# Altium schematic sheet ESC.SchDoc
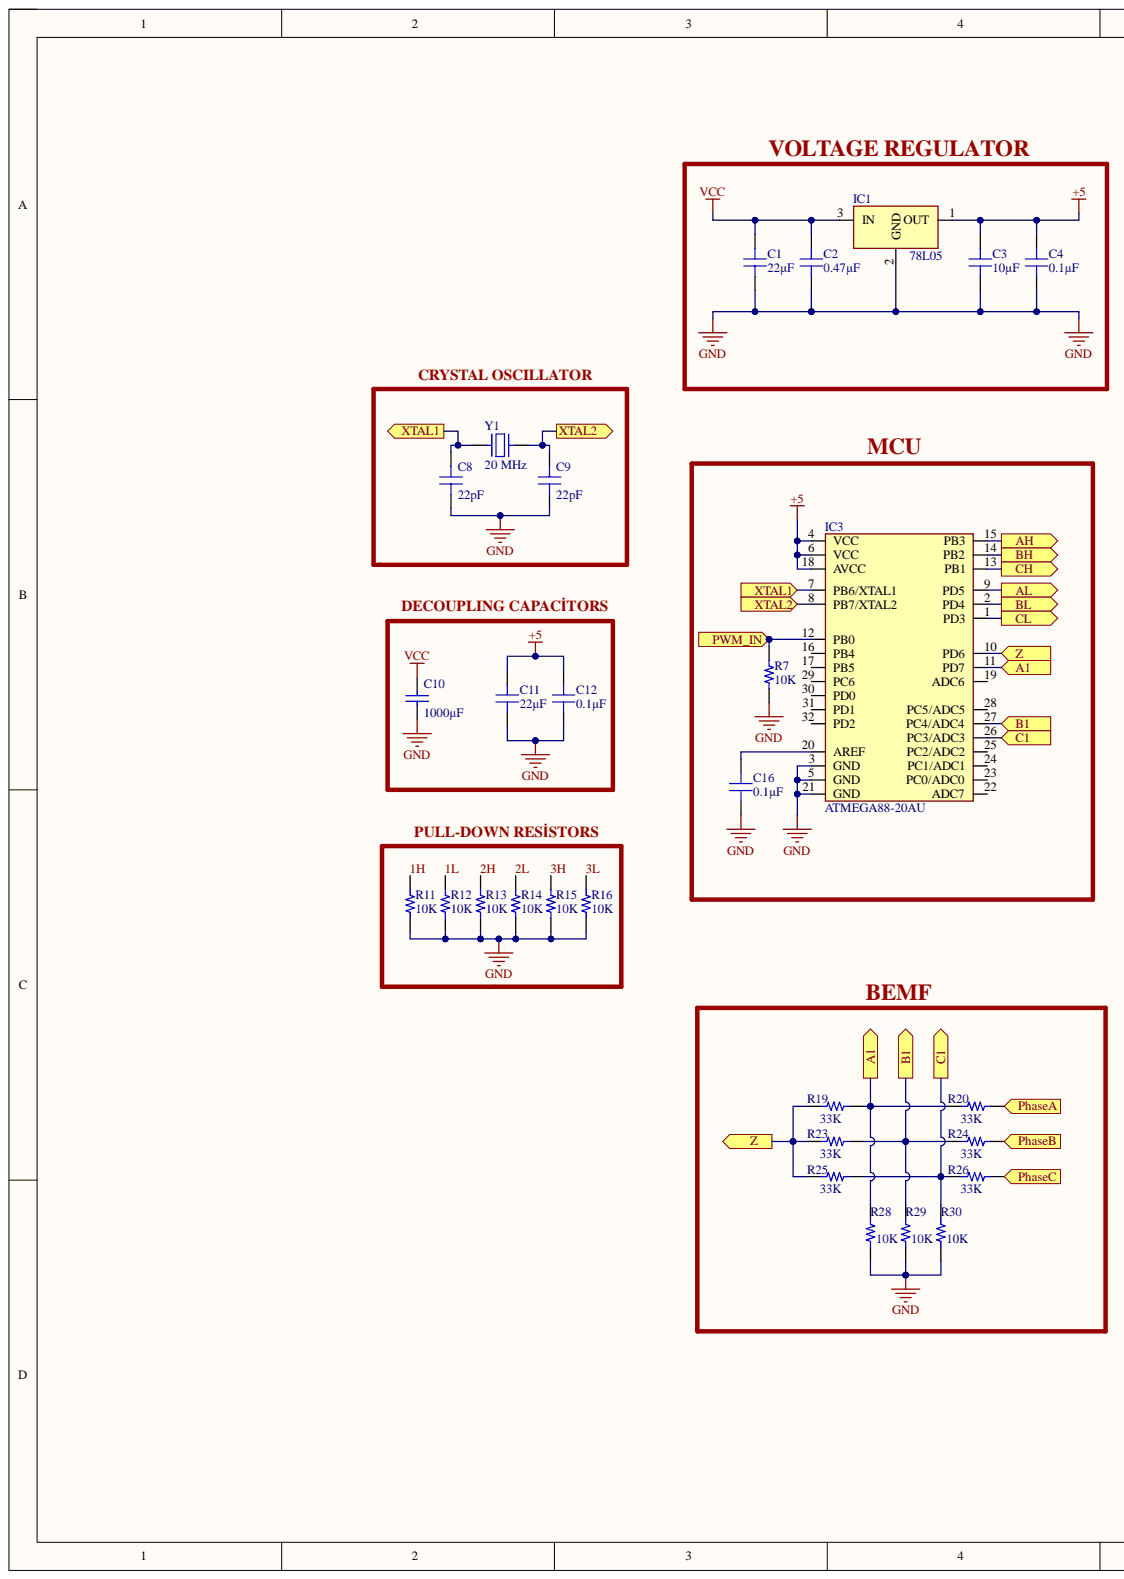
[diagram p0: ESC.SchDoc, left]
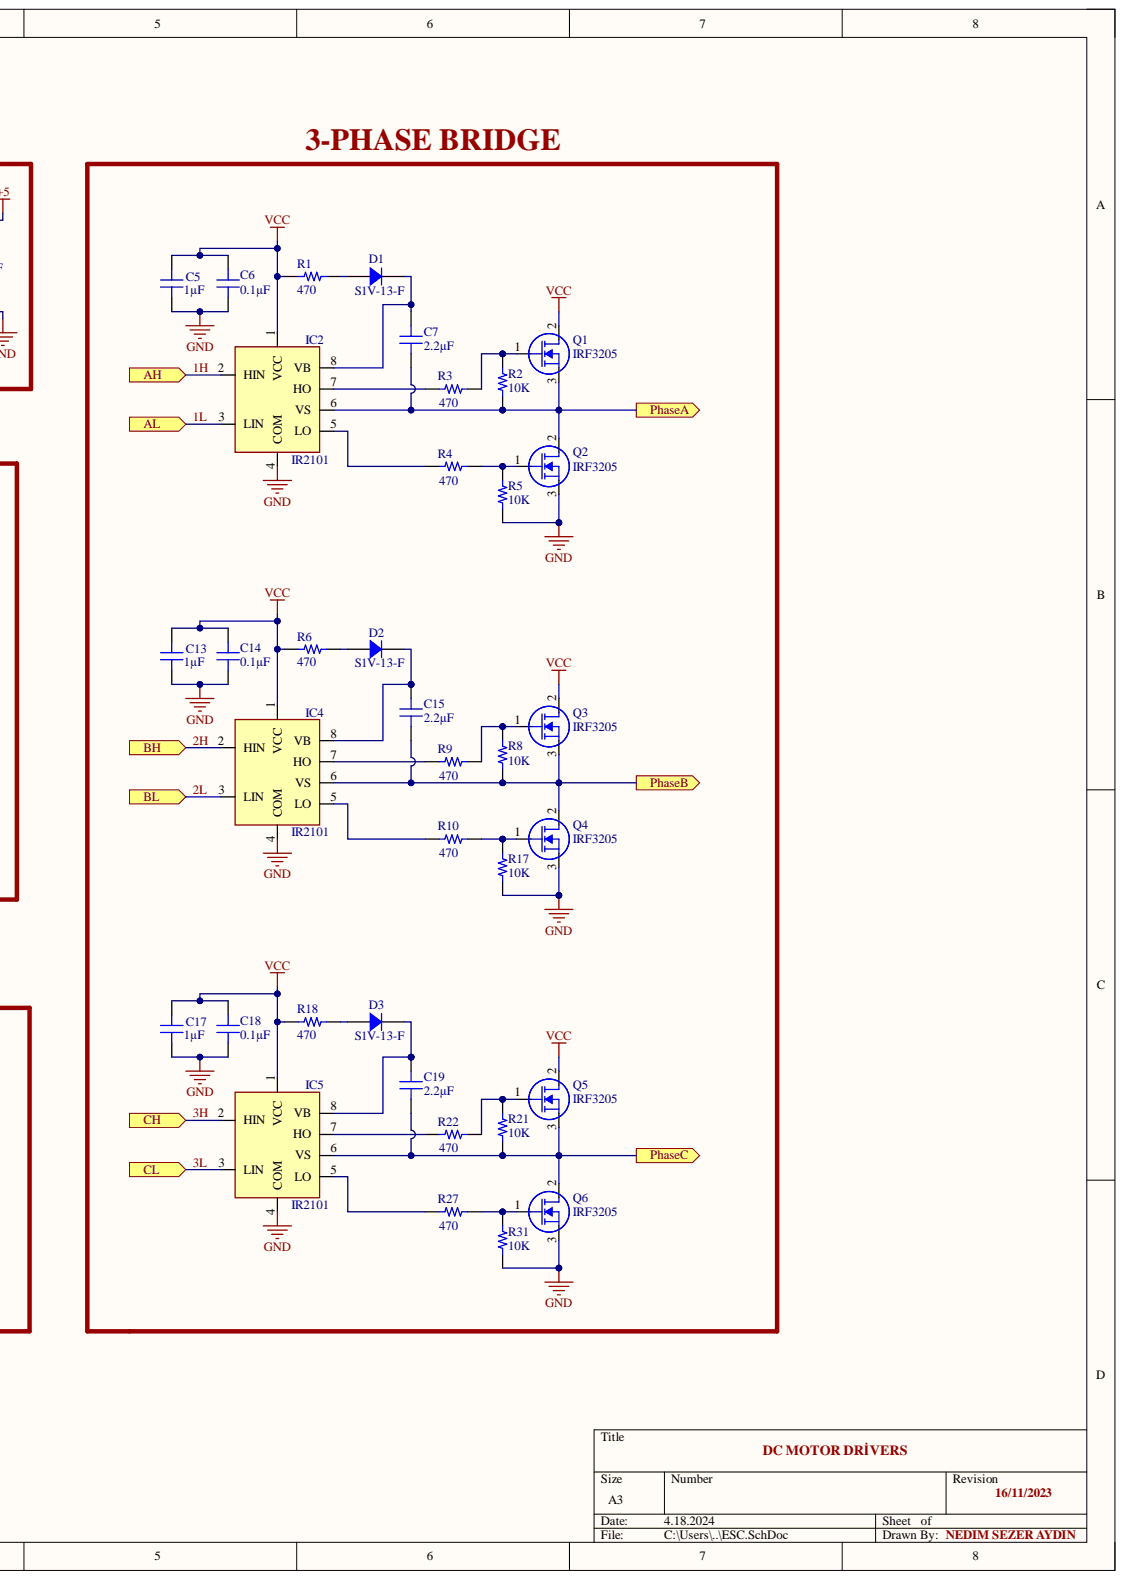
[diagram p1: ESC.SchDoc, right]

## Parts
C1  22µF  [CAP 1206_3216 - 0.8MM]
C2  0.47µF  [CAP 1206_3216 - 0.8MM]
C3  10µF  [CAP 1206_3216 - 0.8MM]
C4  0.1µF  [CAP 1206_3216 - 0.8MM]
C5  1µF  [CAP 1210_3225 - 1MM]
C6  0.1µF  [CAP 1210_3225 - 1MM]
C7  2.2µF  [CAP 1206_3216 - 0.8MM]
C8  22pF  [CAP 1206_3216 - 0.8MM]
C9  22pF  [CAP 1206_3216 - 0.8MM]
C10  value 1000µF  (hidden comment: Capacitor)
C11  22µF  [CAP 1206_3216 - 0.8MM]
C12  0.1µF  [CAP 1206_3216 - 0.8MM]
C13  1µF  [CAP 1210_3225 - 1MM]
C14  0.1µF  [CAP 1210_3225 - 1MM]
C15  2.2µF  [CAP 1206_3216 - 0.8MM]
C16  0.1µF  [CAP 1206_3216 - 0.8MM]
C17  1µF  [CAP 1210_3225 - 1MM]
C18  0.1µF  [CAP 1210_3225 - 1MM]
C19  2.2µF  [CAP 1206_3216 - 0.8MM]
D1  S1V-13-F  [DO214AC SMA]
D2  S1V-13-F  [DO214AC SMA]
D3  S1V-13-F  [DO214AC SMA]
IC1  78L05  [SOT89-150P410X160-3N]
IC2  IR2101  [SOIC127P600X175-8N]
IC3  ATMEGA88-20AU
IC4  IR2101  [SOIC127P600X175-8N]
IC5  IR2101  [SOIC127P600X175-8N]
Q1  IRF3205  [IRF3205S]
Q2  IRF3205  [IRF3205S]
Q3  IRF3205  [IRF3205S]
Q4  IRF3205  [IRF3205S]
Q5  IRF3205  [IRF3205S]
Q6  IRF3205  [IRF3205S]
R1  470  [RES 1210_3225]
R2  10K  [RES 1206_3216]
R3  470  [RES 1210_3225]
R4  470  [RES 1210_3225]
R5  10K  [RES 1206_3216]
R6  470  [RES 1210_3225]
R7  10K  [RES 1206_3216]
R8  10K  [RES 1206_3216]
R9  470  [RES 1210_3225]
R10  470  [RES 1210_3225]
R11  10K  [RES 1206_3216]
R12  10K  [RES 1206_3216]
R13  10K  [RES 1206_3216]
R14  10K  [RES 1206_3216]
R15  10K  [RES 1206_3216]
R16  10K  [RES 1206_3216]
R17  10K  [RES 1206_3216]
R18  470  [RES 1210_3225]
R19  33K  [RES 1206_3216]
R20  33K  [RES 1206_3216]
R21  10K  [RES 1206_3216]
R22  470  [RES 1210_3225]
R23  33K  [RES 1206_3216]
R24  33K  [RES 1206_3216]
R25  33K  [RES 1206_3216]
R26  33K  [RES 1206_3216]
R27  470  [RES 1210_3225]
R28  10K  [RES 1206_3216]
R29  10K  [RES 1206_3216]
R30  10K  [RES 1206_3216]
R31  10K  [RES 1206_3216]
Y1  20 MHz  [NDK NX5032GA]

## Ports
A1, AH, AL, B1, BH, BL, C1, CH, CL, PhaseA, PhaseB, PhaseC, PWM_IN, XTAL1, XTAL2, Z

## Nets
+5: C3.2 C4.2 C11.2 C12.2 IC1.1 IC3.4(VCC) IC3.6(VCC) IC3.18(AVCC)
GND: C1.1 C2.1 C3.1 C4.1 C5.1 C6.1 C8.1 C9.1 C10.1 C11.1 C12.1 C13.1 C14.1 C16.1 C17.1 C18.1 IC1.2 IC2.4(COM) IC3.3(GND) IC3.5(GND) IC3.21(GND) IC4.4(COM) IC5.4(COM) Q2.3 Q4.3 Q6.3 R5.1 R7.1 R11.1 R12.1 R13.1 R14.1 R15.1 R16.1 R17.1 R28.1 R29.1 R30.1 R31.1
VCC: C1.2 C2.2 C5.2 C6.2 C10.2 C13.2 C14.2 C17.2 C18.2 IC1.3 IC2.1(VCC) IC4.1(VCC) IC5.1(VCC) Q1.2 Q3.2 Q5.2 R1.1 R6.1 R18.1
1H: IC2.2(HIN) IC3.15(PB3) R11.2 ; port AH
1L: IC2.3(LIN) IC3.9(PD5) R12.2 ; port AL
2H: IC3.14(PB2) IC4.2(HIN) R13.2 ; port BH
2L: IC3.2(PD4) IC4.3(LIN) R14.2 ; port BL
3H: IC3.13(PB1) IC5.2(HIN) R15.2 ; port CH
3L: IC3.1(PD3) IC5.3(LIN) R16.2 ; port CL
A1: IC3.11(PD7) R19.2 R20.1 R28.2 ; port A1
B1: IC3.27(PC4/ADC4) R23.2 R24.1 R29.2 ; port B1
C1: IC3.26(PC3/ADC3) R25.2 R26.1 R30.2 ; port C1
PhaseA: C7.1 IC2.6(VS) Q1.3 Q2.2 R2.1 R20.2 ; port PhaseA
PhaseB: C15.1 IC4.6(VS) Q3.3 Q4.2 R8.1 R24.2 ; port PhaseB
PhaseC: C19.1 IC5.6(VS) Q5.3 Q6.2 R21.1 R26.2 ; port PhaseC
PWM_IN: IC3.12(PB0) R7.2 ; port PWM_IN
XTAL1: C8.2 IC3.7(PB6/XTAL1) Y1.1 ; port XTAL1
XTAL2: C9.2 IC3.8(PB7/XTAL2) Y1.2 ; port XTAL2
Z: IC3.10(PD6) R19.1 R23.1 R25.1 ; port Z
NetC7_2: C7.2 D1.2 IC2.8(VB)
NetC15_2: C15.2 D2.2 IC4.8(VB)
NetC16_2: C16.2 IC3.20(AREF)
NetC19_2: C19.2 D3.2 IC5.8(VB)
NetD1_1: D1.1 R1.2
NetD2_1: D2.1 R6.2
NetD3_1: D3.1 R18.2
NetQ1_1: Q1.1 R2.2 R3.2
NetQ2_1: Q2.1 R4.2 R5.2
NetQ3_1: Q3.1 R8.2 R9.2
NetQ4_1: Q4.1 R10.2 R17.2
NetQ5_1: Q5.1 R21.2 R22.2
NetQ6_1: Q6.1 R27.2 R31.2
NetR3_1: IC2.7(HO) R3.1
NetR4_1: IC2.5(LO) R4.1
NetR9_1: IC4.7(HO) R9.1
NetR10_1: IC4.5(LO) R10.1
NetR22_1: IC5.7(HO) R22.1
NetR27_1: IC5.5(LO) R27.1

Not connected: IC3 16(PB4) 17(PB5) 19(ADC6) 22(ADC7) 23(PC0/ADC0) 24(PC1/ADC1) 25(PC2/ADC2) 28(PC5/ADC5) 29(PC6) 30(PD0) 31(PD1) 32(PD2)

## Library data of the parts
C1 C11 = CL31X226KAHN3NE: CAP CER 22UF 25V X6S 1206; manufacturer: Samsung Electro-Mechanics; Database Table Name: Capacitors - Ceramic - 1206; Tolerance: ±10%; Applications: General Purpose; Capacitance: 22 µF; Component Kind: Standard; componentlink1description: Datasheet; componentlink1url: http://www.samsungsem.com/kr/support/product-search/mlcc/CL31X226KAHN3NE.jsp; componentlink2description: DigiKey Link; componentlink2url: https://www.digikey.com/en/products/detail/samsung-electro-mechanics/CL31X226KAHN3NE/3888957; Device Package: 1206 (3216 Metric); lastupdated: 2.01.2024 20:18:31; Library Name: Celestial Altium Library - altium_library.DbLib; Lifecycle Status: Active; Minimum Order: 1; Mounting Type: Surface Mount, MLCC; Operating Temperature: -55°C ~ 105°C; Package / Case: 1206 (3216 Metric); packaging: TapeAndReel; Part Number: CL31X226KAHN3NE; Part Status: Active; partid: 21657; price: 0.17; series: CL; Size / Dimension: 0.126" L x 0.063" W (3.20mm x 1.60mm); Supplier 1: DigiKey; Supplier Part Number 1: 1276-3299-1-ND; Temperature Coefficient: X6S; Thickness (Max): 0.071" (1.80mm); Value: 22µF; Voltage - Rated: 25V
C2 = C1206C474K1REC7210: CAP CER 1206 470NF 100V X7R; manufacturer: KEMET; Database Table Name: Capacitors - Ceramic - 1206; Tolerance: ±10%; Applications: ESD Protection; Capacitance: 0.47 µF; Component Kind: Standard; componentlink1description: Datasheet; componentlink1url: https://api.kemet.com/component-edge/download/datasheet/C1206C474K1REC7210.pdf; componentlink2description: DigiKey Link; componentlink2url: https://www.digikey.com/en/products/detail/kemet/C1206C474K1REC7210/8645666; Device Package: 1206 (3216 Metric); lastupdated: 2.01.2024 20:18:03; Library Name: Celestial Altium Library - altium_library.DbLib; Lifecycle Status: Active; Minimum Order: 1; Mounting Type: Surface Mount, MLCC; Operating Temperature: -55°C ~ 125°C; Package / Case: 1206 (3216 Metric); packaging: TapeAndReel; Part Number: C1206C474K1REC7210; Part Status: Active; partid: 30065; price: 0.06; series: ESD SMD Comm X7R; Size / Dimension: 0.126" L x 0.063" W (3.20mm x 1.60mm); Supplier 1: DigiKey; Supplier Part Number 1: 399-C1206C474K1REC7210CT-ND; Temperature Coefficient: X7R; Thickness (Max): 0.071" (1.80mm); Value: 0.47µF; Voltage - Rated: 100V
C3 = GRM31CR71E106KA12K: CAP CER 10UF 25V X7R 1206; manufacturer: Murata Electronics; Database Table Name: Capacitors - Ceramic - 1206; Tolerance: ±10%; Applications: General Purpose; Capacitance: 10 µF; Component Kind: Standard; componentlink1description: Datasheet; componentlink1url: https://search.murata.co.jp/Ceramy/image/img/A01X/G101/ENG/GRM31CR71E106KA12-01.pdf; componentlink2description: DigiKey Link; componentlink2url: https://www.digikey.com/en/products/detail/murata-electronics/GRM31CR71E106KA12K/4905618; Device Package: 1206 (3216 Metric); lastupdated: 2.01.2024 20:18:47; Library Name: Celestial Altium Library - altium_library.DbLib; Lifecycle Status: Active; Minimum Order: 1; Mounting Type: Surface Mount, MLCC; Operating Temperature: -55°C ~ 125°C; Package / Case: 1206 (3216 Metric); packaging: TapeAndReel; Part Number: GRM31CR71E106KA12K; Part Status: Active; partid: 19493; price: 0.05; series: GRM; Size / Dimension: 0.126" L x 0.063" W (3.20mm x 1.60mm); Supplier 1: DigiKey; Supplier Part Number 1: 490-14678-1-ND; Temperature Coefficient: X7R; Thickness (Max): 0.071" (1.80mm); Value: 10µF; Voltage - Rated: 25V
C4 C12 = C1206F104K3RACAUTO: CAP CER 0.1UF 25V X7R 1206; manufacturer: KEMET; Database Table Name: Capacitors - Ceramic - 1206; Tolerance: ±10%; Applications: Automotive; Capacitance: 0.1 µF; Component Kind: Standard; componentlink1description: Datasheet; componentlink1url: https://api.kemet.com/component-edge/download/datasheet/C1206F104K3RACAUTO.pdf; componentlink2description: DigiKey Link; componentlink2url: https://www.digikey.com/en/products/detail/kemet/C1206F104K3RACAUTO/9925523; Device Package: 1206 (3216 Metric); Features: Open Mode; lastupdated: 2.01.2024 20:18:42; Library Name: Celestial Altium Library - altium_library.DbLib; Lifecycle Status: Active; Minimum Order: 1; Mounting Type: Surface Mount, MLCC; Operating Temperature: -55°C ~ 125°C; Package / Case: 1206 (3216 Metric); packaging: TapeAndReel; Part Number: C1206F104K3RACAUTO; Part Status: Active; partid: 18919; price: 0.08; Ratings: AEC-Q200; series: SMD Auto X7R FO; Size / Dimension: 0.126" L x 0.063" W (3.20mm x 1.60mm); Supplier 1: DigiKey; Supplier Part Number 1: 399-20231-1-ND; Temperature Coefficient: X7R; Thickness (Max): 0.043" (1.10mm); Value: 0.1µF; Voltage - Rated: 25V
C5 C13 C17 = C3225X7R2A105K200AE: CAP CER 1UF 100V X7R 1210; manufacturer: TDK Corporation; Database Table Name: Capacitors - Ceramic - 1210; Tolerance: ±10%; Applications: Boardflex Sensitive; Capacitance: 1 µF; Component Kind: Standard; componentlink1description: Datasheet; componentlink1url: https://product.tdk.com/info/en/catalog/datasheets/mlcc_commercial_soft_en.pdf?ref_disty=digikey; componentlink2description: DigiKey Link; componentlink2url: https://www.digikey.com/en/products/detail/tdk-corporation/C3225X7R2A105K200AE/5809217; Device Package: 1210 (3225 Metric); Features: Soft Termination; lastupdated: 28.12.2023 20:41:16; Library Name: Celestial Altium Library - altium_library.DbLib; Lifecycle Status: Active; Minimum Order: 1; Mounting Type: Surface Mount, MLCC; Operating Temperature: -55°C ~ 125°C; Package / Case: 1210 (3225 Metric); packaging: TapeAndReel; Part Number: C3225X7R2A105K200AE; Part Status: Active; partid: 24299; price: 0.15; series: C; Size / Dimension: 0.126" L x 0.098" W (3.20mm x 2.50mm); Supplier 1: DigiKey; Supplier Part Number 1: 445-173652-1-ND; Temperature Coefficient: X7R; Thickness (Max): 0.091" (2.30mm); Value: 1µF; Voltage - Rated: 100V
C6 C14 C18 = C1210X104KBRAC7800: CAP CER 0.1UF 630V X7R 1210 10%; manufacturer: KEMET; Database Table Name: Capacitors - Ceramic - 1210; Applications: Boardflex Sensitive; Capacitance: 0.1 µF; Component Kind: Standard; componentlink1description: Datasheet; componentlink1url: https://api.kemet.com/component-edge/download/datasheet/C1210X104KBRAC7800.pdf; componentlink2description: DigiKey Link; componentlink2url: https://www.digikey.com/en/products/detail/kemet/C1210X104KBRAC7800/7652851; Device Package: 1210 (3225 Metric); Features: Low ESL, Soft Termination, High Voltage; lastupdated: 28.12.2023 20:41:14; Library Name: Celestial Altium Library - altium_library.DbLib; Lifecycle Status: Active; Minimum Order: 1; Mounting Type: Surface Mount, MLCC; Operating Temperature: -55°C ~ 125°C; Package / Case: 1210 (3225 Metric); packaging: TapeAndReel; Part Number: C1210X104KBRAC7800; Part Status: Active; partid: 23867; price: 0.16; series: SMD Comm X7R HV Flex; Size / Dimension: 0.130" L x 0.102" W (3.30mm x 2.60mm); Supplier 1: DigiKey; Supplier Part Number 1: 399-16358-1-ND; Temperature Coefficient: X7R; Thickness (Max): 0.110" (2.80mm); Tolerance: ±10%; Value: 0.1µF; Voltage - Rated: 630V
C7 C15 C19 = CC1206MRY5V8BB225: CAP CER 2.2UF 25V Y5V 1206; manufacturer: Yageo; Database Table Name: Capacitors - Ceramic - 1206; Temperature Coefficient: Y5V (F); Tolerance: ±20%; Applications: General Purpose; Capacitance: 2.2 µF; Component Kind: Standard; componentlink1description: Datasheet; componentlink1url: http://www.yageo.com/documents/recent/UPY-GPHC_Y5V_6.3V-to-50V_8.pdf; componentlink2description: DigiKey Link; componentlink2url: https://www.digikey.com/en/products/detail/yageo/CC1206MRY5V8BB225/7164412; Device Package: 1206 (3216 Metric); lastupdated: 2.01.2024 20:18:42; Library Name: Celestial Altium Library - altium_library.DbLib; Lifecycle Status: Active; Minimum Order: 1; Mounting Type: Surface Mount, MLCC; Operating Temperature: -30°C ~ 85°C; Package / Case: 1206 (3216 Metric); packaging: TapeAndReel; Part Number: CC1206MRY5V8BB225; Part Status: Active; partid: 19235; price: 0.04; series: CC; Size / Dimension: 0.126" L x 0.063" W (3.20mm x 1.60mm); Supplier 1: DigiKey; Supplier Part Number 1: 311-3528-1-ND; Thickness (Max): 0.037" (0.95mm); Value: 2.2µF; Voltage - Rated: 25V
C8 C9 = CC1206JRNPO9BN220: CAP CER 22PF 50V C0G/NPO 1206; manufacturer: Yageo; Database Table Name: Capacitors - Ceramic - 1206; Temperature Coefficient: C0G, NP0; Tolerance: ±5%; Applications: General Purpose; Capacitance: 22 pF; Component Kind: Standard; componentlink1description: Datasheet; componentlink1url: https://www.yageo.com/upload/media/product/productsearch/datasheet/mlcc/UPY-GP_NP0_16V-to-50V_17.pdf; componentlink2description: DigiKey Link; componentlink2url: https://www.digikey.com/en/products/detail/yageo/CC1206JRNPO9BN220/302888; Device Package: 1206 (3216 Metric); lastupdated: 2.01.2024 20:18:39; Library Name: Celestial Altium Library - altium_library.DbLib; Lifecycle Status: Active; Minimum Order: 1; Mounting Type: Surface Mount, MLCC; Operating Temperature: -55°C ~ 125°C; Package / Case: 1206 (3216 Metric); packaging: TapeAndReel; Part Number: CC1206JRNPO9BN220; Part Status: Active; partid: 18729; price: 0.03; series: CC; Size / Dimension: 0.126" L x 0.063" W (3.20mm x 1.60mm); Supplier 1: DigiKey; Supplier Part Number 1: 311-1154-1-ND; Thickness (Max): 0.024" (0.60mm); Value: 22pF; Voltage - Rated: 50V
C10 = Capacitor: SimulationGenericComponent: True; Component Kind: Standard; Description: Capacitor; Library Name: Simulation Generic Components.IntLib; Simulation: C_Capacitor_Default
C16 = CC1206MRY5V9BB104: CAP CER 0.1UF 50V Y5V 1206; manufacturer: Yageo; Database Table Name: Capacitors - Ceramic - 1206; Temperature Coefficient: Y5V (F); Tolerance: ±20%; Applications: General Purpose; Capacitance: 0.1 µF; Component Kind: Standard; componentlink1description: Datasheet; componentlink1url: http://www.yageo.com/documents/recent/UPY-GPHC_Y5V_6.3V-to-50V_8.pdf; componentlink2description: DigiKey Link; componentlink2url: https://www.digikey.com/en/products/detail/yageo/CC1206MRY5V9BB104/5884674; Device Package: 1206 (3216 Metric); lastupdated: 2.01.2024 20:18:42; Library Name: Celestial Altium Library - altium_library.DbLib; Lifecycle Status: Active; Minimum Order: 1; Mounting Type: Surface Mount, MLCC; Operating Temperature: -30°C ~ 85°C; Package / Case: 1206 (3216 Metric); packaging: TapeAndReel; Part Number: CC1206MRY5V9BB104; Part Status: Active; partid: 18711; price: 0.04; series: CC; Size / Dimension: 0.126" L x 0.063" W (3.20mm x 1.60mm); Supplier 1: DigiKey; Supplier Part Number 1: 311-4504-1-ND; Thickness (Max): 0.028" (0.70mm); Value: 0.1µF; Voltage - Rated: 50V
D1-D3 = S1V-13-F: DIODE GEN PURP 2KV 1A SMA; Manufacturer: Diodes Incorporated; Capacitance @ Vr, F: 4pF @ 4V, 1MHz; Current - Reverse Leakage @ Vr: 5 µA @ 2000 V; Database Table Name: Diodes - Rectifiers - Single; Diode Type: Standard; Speed: Standard Recovery >500ns, > 200mA (Io); Voltage - DC Reverse (Vr) (Max): 2000 V; Component Kind: Standard; ComponentLink1Description: Datasheet; ComponentLink1URL: https://www.diodes.com/assets/Datasheets/S1V.pdf; ComponentLink2Description: DigiKey Link; ComponentLink2URL: https://www.digikey.com/en/products/detail/diodes-incorporated/S1V-13-F/9089780; Current - Average Rectified (Io): 1A; LastUpdated: 28.12.2023 21:20:57; Library Name: Celestial Altium Library - altium_library.DbLib; Lifecycle Status: Active; Minimum Order: 1; Mounting Type: Surface Mount; Operating Temperature - Junction: -55°C ~ 150°C; Package / Case: DO-214AC, SMA; Packaging: TapeAndReel; Part Number: S1V-13-F; Part Status: Active; PartId: 588; Price: 0.06; Series: -; Supplier 1: DigiKey; Supplier Device Package: SMA; Supplier Part Number 1: S1V-13-FDICT-ND
IC2 IC4 IC5 = Gate Driver: Component Kind: Standard; Library Name: AMP.SchLib
Q1-Q6 = IRF3205S: Component Kind: Standard; Library Name: AMP.SchLib
R1 R3 R4 R6 R9 R10 R18 R22 R27 = RMCF1210FT470R: RES 470 OHM 1% 1/2W 1210; Manufacturer: Stackpole Electronics Inc; Database Table Name: Resistors - Surface Mount - 1210; Power (Watts): 0.5W, 1/2W; Resistance: 470 Ohms; Temperature Coefficient: ±100ppm/°C; Value: 470 Ohms; Component Kind: Standard; ComponentLink1Description: Datasheet; ComponentLink1URL: https://www.seielect.com/catalog/sei-rmcf_rmcp.pdf; ComponentLink2Description: DigiKey Link; ComponentLink2URL: https://www.digikey.com/en/products/detail/stackpole-electronics-inc/RMCF1210FT470R/1758734; Composition: Thick Film; Device Package: 1210 (3225 Metric); Features: Automotive AEC-Q200; Height - Seated (Max): 0.028" (0.70mm); Library Name: Celestial Altium Library - altium_library.DbLib; Lifecycle Status: Active; Minimum Order: 1; Number of Terminations: 2; Operating Temperature: -55°C ~ 155°C; Package / Case: 1210 (3225 Metric); Packaging: TapeAndReel; Part Number: RMCF1210FT470R; Part Status: Active; Price: 0.01; Ratings: AEC-Q200; Series: RMCF; Size / Dimension: 0.126" L x 0.098" W (3.20mm x 2.50mm); Supplier 1: DigiKey; Supplier Device Package: 1210; Supplier Part Number 1: RMCF1210FT470RCT-ND; Tolerance: ±1%
  R1 R6 R18: LastUpdated: 2.01.2024 21:49:53
  R3 R4 R9 R10 R22 R27: LastUpdated: 28.12.2023 21:42:13
R2 R5 R7 R8 R11-R17 R21 R28-R31 = CRG1206F10K: RES SMD 10K OHM 1% 1/4W 1206; Manufacturer: TE Connectivity Passive Product; Database Table Name: Resistors - Surface Mount - 1206; Power (Watts): 0.25W, 1/4W; Resistance: 10 kOhms; Temperature Coefficient: ±100ppm/°C; Value: 10 kOhms; Component Kind: Standard; ComponentLink1Description: Datasheet; ComponentLink1URL: https://www.te.com/commerce/DocumentDelivery/DDEController?Action=srchrtrv&DocNm=1773204&DocType=DS&DocLang=English; ComponentLink2Description: DigiKey Link; ComponentLink2URL: https://www.digikey.com/en/products/detail/te-connectivity-passive-product/CRG1206F10K/2389909; Composition: Thick Film; Device Package: 1206 (3216 Metric); Height - Seated (Max): 0.026" (0.65mm); Library Name: Celestial Altium Library - altium_library.DbLib; Lifecycle Status: Active; Minimum Order: 1; Number of Terminations: 2; Operating Temperature: -55°C ~ 155°C; Package / Case: 1206 (3216 Metric); Packaging: TapeAndReel; Part Number: CRG1206F10K; Part Status: Active; PartId: 26989; Price: 0.01; Series: CRG, Neohm; Size / Dimension: 0.122" L x 0.061" W (3.10mm x 1.55mm); Supplier 1: DigiKey; Supplier Device Package: 1206; Supplier Part Number 1: A106069CT-ND; Tolerance: ±1%
  R2 R5 R8 R17 R21 R31: LastUpdated: 28.12.2023 20:50:08
  R7 R11-R16 R28-R30: LastUpdated: 2.01.2024 20:52:21
R19 R20 R23-R26 = RT1206BRD0733KL: RES SMD 33K OHM 0.1% 1/4W 1206; Manufacturer: Yageo; Database Table Name: Resistors - Surface Mount - 1206; Power (Watts): 0.25W, 1/4W; Resistance: 33 kOhms; Temperature Coefficient: ±25ppm/°C; Value: 33 kOhms; Component Kind: Standard; ComponentLink1Description: Datasheet; ComponentLink1URL: https://www.yageo.com/upload/media/product/productsearch/datasheet/rchip/PYu-RT_1-to-0.01_RoHS_L_11.pdf; ComponentLink2Description: DigiKey Link; ComponentLink2URL: https://www.digikey.com/en/products/detail/yageo/RT1206BRD0733KL/1079747; Composition: Thin Film; Device Package: 1206 (3216 Metric); Height - Seated (Max): 0.026" (0.65mm); LastUpdated: 2.01.2024 20:52:22; Library Name: Celestial Altium Library - altium_library.DbLib; Lifecycle Status: Active; Minimum Order: 1; Number of Terminations: 2; Operating Temperature: -55°C ~ 155°C; Package / Case: 1206 (3216 Metric); Packaging: TapeAndReel; Part Number: RT1206BRD0733KL; Part Status: Active; PartId: 26862; Price: 0.09; Series: RT; Size / Dimension: 0.122" L x 0.063" W (3.10mm x 1.60mm); Supplier 1: DigiKey; Supplier Device Package: 1206; Supplier Part Number 1: YAG2038CT-ND; Tolerance: ±0.1%
Y1 = STDCSK4-20M: CRYSTAL 20.0000MHZ 8PF SMD; Manufacturer: NDK America, Inc.; Database Table Name: Oscillators - Crystals; ESR (Equivalent Series Resistance): 50 Ohms; Frequency Stability: ±30ppm; Frequency Tolerance: ±20ppm; Operating Mode: Fundamental; Component Kind: Standard; ComponentLink1Description: Datasheet; ComponentLink1URL: https://www.ndk.com/images/products/catalog/c_NX5032GA_e.pdf; ComponentLink2Description: DigiKey Link; ComponentLink2URL: https://www.digikey.com/en/products/detail/ndk-america-inc/STDCSK4-20M/5022976; Frequency: 20 MHz; Height - Seated (Max): 0.059" (1.50mm); LastUpdated: 2.01.2024 21:34:39; Library Name: Celestial Altium Library - altium_library.DbLib; Lifecycle Status: Active; Load Capacitance: 8pF; Minimum Order: 1; Mounting Type: Surface Mount; Operating Temperature: -10°C ~ 70°C; Packaging: TapeAndReel; Part Number: STDCSK4-20M; PartId: 967; Price: 0.32; Series: NX5032GA; Size / Dimension: 0.197" L x 0.126" W (5.00mm x 3.20mm); Supplier 1: DigiKey; Supplier Part Number 1: 644-STDCSK4-20MCT-ND; Type: MHz Crystal; Value: 20 MHz
IC1 = 78L05: Component Kind: Standard; Library Name: AMP.SchLib
IC3 = ATMEGA88-20AU: Component Kind: Standard; Library Name: AMP.SchLib
